# Altium schematic sheet usb_can.SchDoc(usb_can)
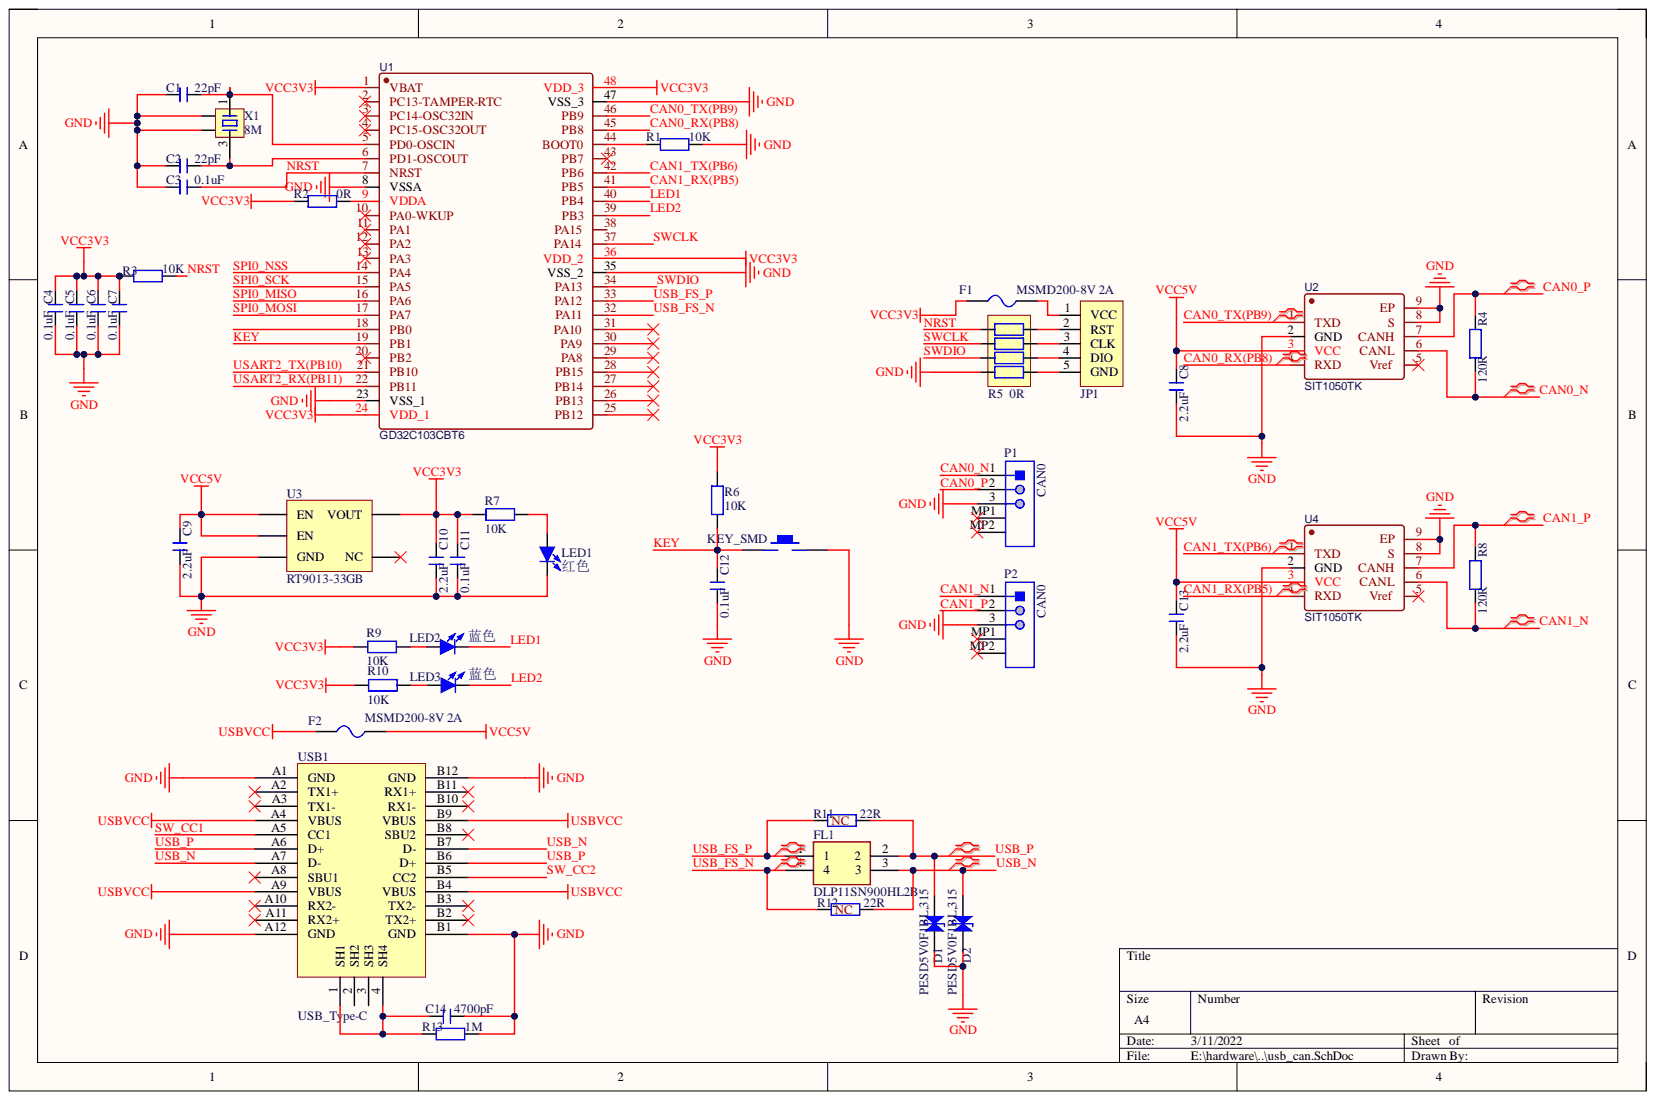
[diagram p0: usb_can.SchDoc]

## Parts
C1  22pF  [C 0402_L]
C2  22pF  [C 0402_L]
C3  0.1uF  [C 0402_L]
C4  0.1uF  [C 0402_L]
C5  0.1uF  [C 0402_L]
C6  0.1uF  [C 0402_L]
C7  0.1uF  [C 0402_L]
C8  2.2uF  [C 0402_L]
C9  2.2uF  [C 0402_L]
C10  2.2uF  [C 0402_L]
C11  0.1uF  [C 0402_L]
C12  0.1uF  [C 0402_L]
C13  2.2uF  [C 0402_L]
C14  4700pF  [C 0402_L]
D1  PESD5V0F1BL,315  [SOD-882_L1.0-W0.6-BI]
D2  PESD5V0F1BL,315  [SOD-882_L1.0-W0.6-BI]
F1  MSMD200-8V 2A  [FU 0805]
F2  MSMD200-8V 2A  [FU 0805]
FL1  DLP11SN900HL2B  [DLP11SA350HL2B]
JP1  HDR-1X5  [HDR-TH_5P-P1.27-V-M]  (value not drawn)
KEY1  KEY_SMD  [SMD-3.2*4.2]
LED1  红色  [LED 0805R]
LED2  蓝色  [LED 0805B]
LED3  蓝色  [LED 0805B]
P1  CAN0  [JST-SM03B-SRSS-TB_V]
P2  CAN0  [JST-SM03B-SRSS-TB_V]
R1  10K  [R 0402_L]
R2  0R  [R 0402_L]
R3  10K  [R 0402_L]
R4  120R  [R 0402_L]
R5  0R  [RCA-8P4R-0402]
R6  10K  [R 0402_L]
R7  10K  [R 0402_L]
R8  120R  [R 0402_L]
R9  10K  [R 0402_L]
R10  10K  [R 0402_L]
R11  22R  [R 0402_L]
R12  22R  [R 0402_L]
R13  1M  [R 0402_L]
U1  GD32C103CBT6  [LQFP-48_L7.0-W7.0-P0.50-LS9.0-BL]
U2  SIT1050TK  [TDFN-8_L3.0-W3.0-P0.65-BL-EP]
U3  RT9013-33GB  [SOT23-5M]
U4  SIT1050TK  [TDFN-8_L3.0-W3.0-P0.65-BL-EP]
USB1  USB_Type-C  [Type-C-TOP]
X1  8M  [OSC 3225-4P]

## Nets
GND: C1.1 C2.1 C3.2 C4.2 C5.2 C6.2 C7.2 C8.2 C9.1 C10.1 C11.1 C12.1 C13.2 C14.1 D1.2 D2.2 KEY1.2 LED1.2 P1.3 P2.3 R1.2 R5.4 R13.1 U1.8(VSSA) U1.23(VSS_1) U1.35(VSS_2) U1.47(VSS_3) U2.2(GND) U2.8(S) U2.9(EP) U3.2(GND) U4.2(GND) U4.8(S) U4.9(EP) USB1.A1(GND) USB1.A12(GND) USB1.B1(GND) USB1.B12(GND) X1.2 X1.4
USBVCC: F2.1 USB1.A4(VBUS) USB1.A9(VBUS) USB1.B4(VBUS) USB1.B9(VBUS)
VCC3V3: C4.1 C5.1 C6.1 C7.1 C10.2 C11.2 F1.1 R2.1 R3.2 R6.1 R7.2 R9.1 R10.1 U1.1(VBAT) U1.24(VDD_1) U1.36(VDD_2) U1.48(VDD_3) U3.5(VOUT)
VCC5V: C8.1 C9.2 C13.1 F2.2 U2.3(VCC) U3.1(EN) U3.3(EN) U4.3(VCC)
CAN0_N: P1.1 R4.2 U2.6(CANL)
CAN0_P: P1.2 R4.1 U2.7(CANH)
CAN0_RX(PB8): U1.45(PB8) U2.4(RXD)
CAN0_TX(PB9): U1.46(PB9) U2.1(TXD)
CAN1_N: P2.1 R8.2 U4.6(CANL)
CAN1_P: P2.2 R8.1 U4.7(CANH)
CAN1_RX(PB5): U1.41(PB5) U4.4(RXD)
CAN1_TX(PB6): U1.42(PB6) U4.1(TXD)
KEY: C12.2 KEY1.1 R6.2 U1.19(PB1)
LED1: LED2.2 U1.40(PB4)
LED2: LED3.2 U1.39(PB3)
NRST: C3.1 R3.1 R5.1 U1.7(NRST)
SPI0_MISO: U1.16(PA6)
SPI0_MOSI: U1.17(PA7)
SPI0_NSS: U1.14(PA4)
SPI0_SCK: U1.15(PA5)
SW_CC1: USB1.A5(CC1)
SW_CC2: USB1.B5(CC2)
SWCLK: R5.2 U1.37(PA14)
SWDIO: R5.3 U1.34(PA13)
USART2_RX(PB11): U1.22(PB11)
USART2_TX(PB10): U1.21(PB10)
USB_FS_N: FL1.4 R12.1 U1.32(PA11)
USB_FS_P: FL1.1 R11.1 U1.33(PA12)
USB_N: D2.1 FL1.3 R12.2 USB1.A7(D-) USB1.B7(D-)
USB_P: D1.1 FL1.2 R11.2 USB1.A6(D+) USB1.B6(D+)
NetC1_2: C1.2 U1.5(PD0-OSCIN) X1.1
NetC2_2: C2.2 U1.6(PD1-OSCOUT) X1.3
NetC14_2: C14.2 R13.2 USB1.1(SH1) USB1.4(SH4)
NetF1_2: F1.2 JP1.1(VCC)
NetJP1_2: JP1.2(RST) R5.8
NetJP1_3: JP1.3(CLK) R5.7
NetJP1_4: JP1.4(DIO) R5.6
NetJP1_5: JP1.5(GND) R5.5
NetLED1_1: LED1.1 R7.1
NetLED2_1: LED2.1 R9.2
NetLED3_1: LED3.1 R10.2
NetR1_1: R1.1 U1.44(BOOT0)
NetR2_2: R2.2 U1.9(VDDA)

Not connected: P1 MP1 MP2; P2 MP1 MP2; U1 2(PC13-TAMPER-RTC) 3(PC14-OSC32IN) 4(PC15-OSC32OUT) 10(PA0-WKUP) 11(PA1) 12(PA2) 13(PA3) 18(PB0) 20(PB2) 25(PB12) 26(PB13) 27(PB14) 28(PB15) 29(PA8) 30(PA9) 31(PA10) 38(PA15) 43(PB7); U2 5(Vref); U3 4(NC); U4 5(Vref); USB1 2(SH2) 3(SH3) A2(TX1+) A3(TX1-) A8(SBU1) A10(RX2-) A11(RX2+) B2(TX2+) B3(TX2-) B8(SBU2) B10(RX1-) B11(RX1+)

## Library data of the parts
C1-C14 = C: 贴片电容; Component Kind: Standard; Library Name: 0.1 - 电阻-电容-电感.SchLib
D1 D2 = PESD5V0F1BL,315: PESD5V0F1BL,315、ESD二极管、5.5V; Component Kind: Standard; Library Name: 0.2 -  二极管-整流桥.SchLib
F1 F2 = FU: 贴片保险丝; Component Kind: Standard; Library Name: 2.0 - 保险丝-晶振-光耦.SchLib
FL1 = DLP11SN900HL2B: Filter; Manufacturer_Name: Murata Electronics; Component Kind: Standard; Datasheet Link: https://www.murata.com/en-us/products/productdetail?partno=DLP11SN900HL2%23; Height: 0.92mm; Manufacturer_Part_Number: DLP11SN900HL2B; Mouser Part Number: 81-DLP11SN900HL2B; Mouser Price/Stock: https://www.mouser.co.uk/ProductDetail/Murata-Electronics/DLP11SN900HL2B?qs=%2F%252BYoplLUB5ikZtvOhZcMEg%3D%3D; Library Name: vSeasky.SchLib
JP1 = SWD: 5P接插件; Component Kind: Standard; Library Name: mx_pcb_library.SchLib
LED1-LED3 = LED-SMD: 贴片LED; Component Kind: Standard; Library Name: 0.3 - LED发光二极管.SchLib
P1 P2 = SM03B-SRSS-TB(LF)(SN): Male Header, Pitch 1 mm, 1 x 3 Position, Height 2.9 mm, -25 to 85 degC, RoHS, Tape and Reel; Manufacturer: JST; No of Positions: 3; Pitch: 1mm; Style/Orientation: RightAngleHeader/Plug; Component Kind: Standard; Datasheet URL: http://www.jst-mfg.com/product/pdf/eng/eSR.pdf; Datasheet Version: 05/2016; Library Name: JST SH Connector.IntLib; Manufacturer URL: http://www.jst.com/home2.html; Mounting Technology: SurfaceMount; Package Description: Male Header, Pitch 1 mm, 1 x 3 Position, Height 2.9 mm; Package Reference: SM03B-SRSS-TB; Termination: Crimp; Type: ShroudedHeader
R1-R4 R6-R13 = R: 贴片电阻; Component Kind: Standard; Library Name: 0.1 - 电阻-电容-电感.SchLib
R5 = R-8P4R: 贴片排阻; Component Kind: Standard; Library Name: 0.1 - 电阻-电容-电感.SchLib
U1 = GD32C103CBT6: BOM_Manufacturer: null; Contributor: LCSC; BOM_JLCPCB Part Class: Extended Part; BOM_Manufacturer Part: GD32C103CBT6; BOM_Supplier: LCSC; BOM_Supplier Part: C2885643; Component Kind: Standard; Library Name: mx_stm32_lib.SchLib; spicePre: U; spiceSymbolName: GD32C103CBT6
U2 U4 = SIT1050TK: BOM_Manufacturer: SIT; Contributor: LCSC; BOM_JLCPCB Part Class: Extended Part; BOM_Manufacturer Part: SIT1050TK; BOM_Supplier: LCSC; BOM_Supplier Part: C427444; Component Kind: Standard; Library Name: mx_pcb_library.SchLib; spicePre: U; spiceSymbolName: SIT1050TK
X1 = XTAL-4P: 4脚无源晶振; Component Kind: Standard; Library Name: 2.0 - 保险丝-晶振-光耦.SchLib
KEY1 = KEY_SMD: Component Kind: Standard; Library Name: mx_pcb_library.SchLib
U3 = RT9013-33GB: Component Kind: Standard; Library Name: mx_pcb_library.SchLib
USB1 = USB_Type-C: Component Kind: Standard; Library Name: mx_pcb_library.SchLib
